# Altium schematic sheet AD9833-DDS.SchDoc
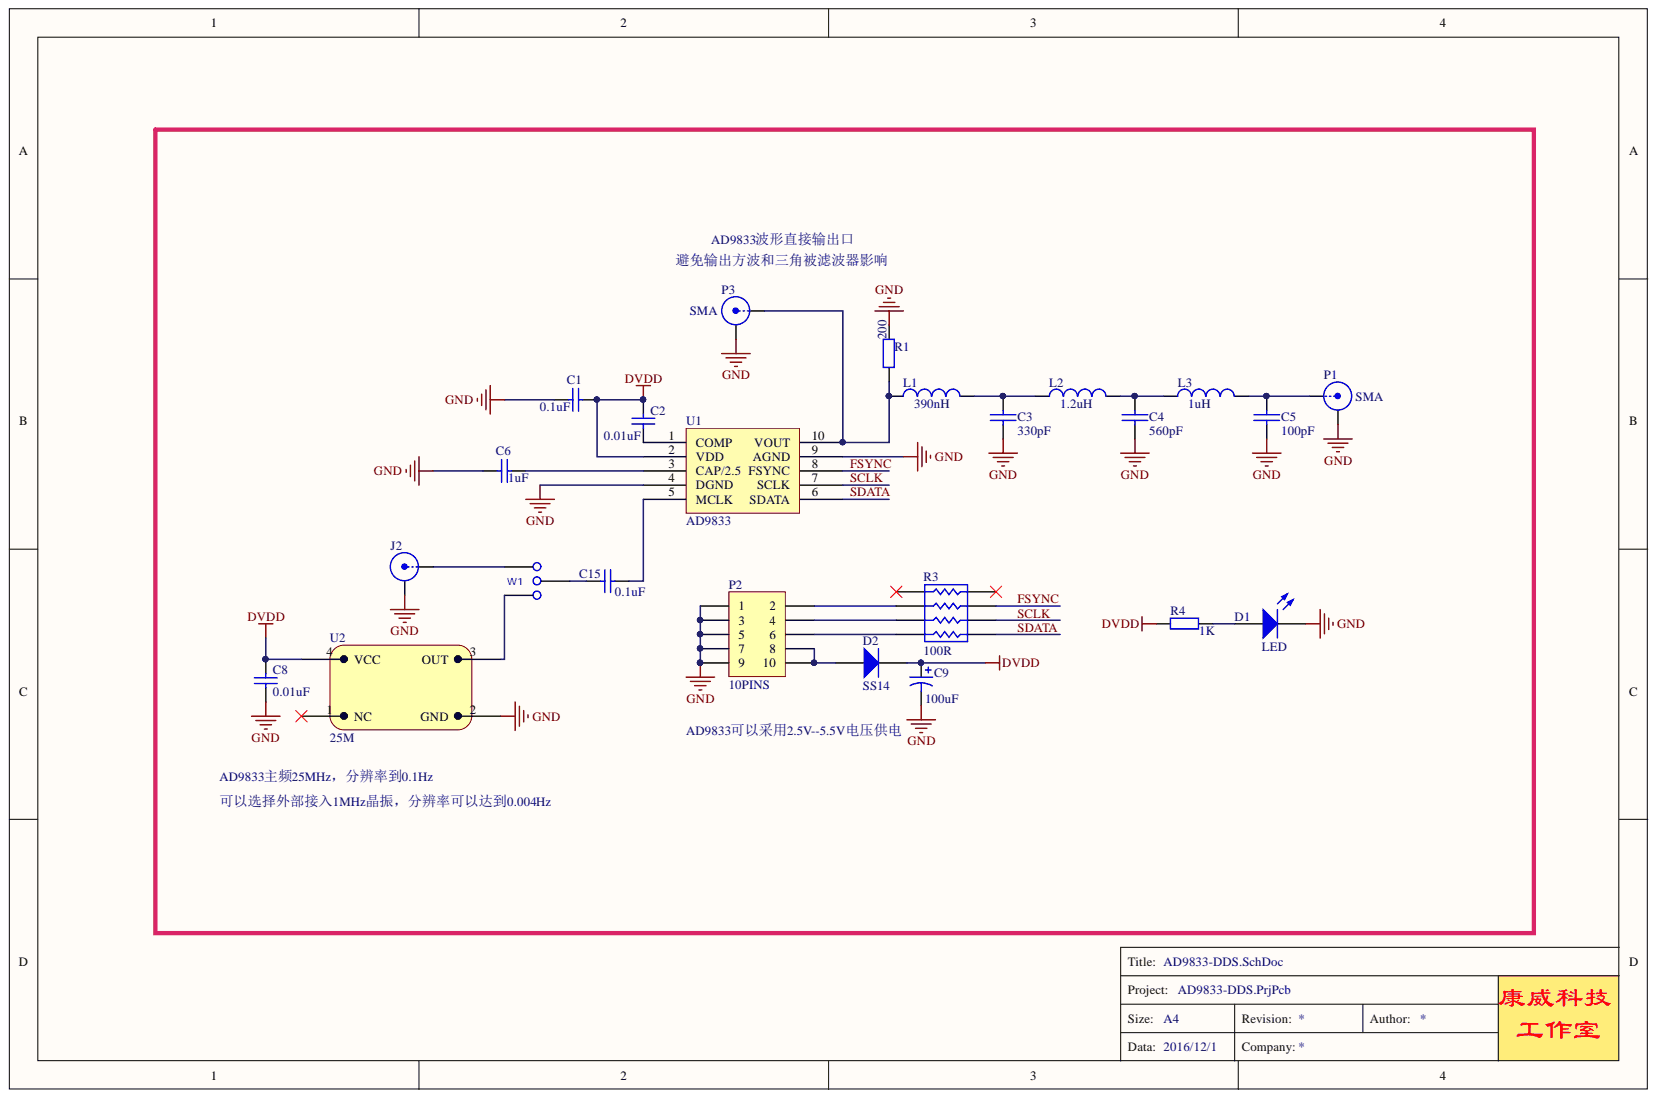
[diagram p0: AD9833-DDS.SchDoc]

## Parts
C1  value 0.1uF  [C0805]
C2  value 0.01uF  [C0805]
C3  330pF  [C0805]
C4  560pF  [C0805]
C5  100pF  [C0805]
C6  value 1uF  [C0805]
C8  value 0.01uF  [C0805]
C9  value 100uF  [C1210]
C15  value 0.1uF  [C0805]
D1  LED  [LED0805R]
D2  SS14  [SOD123]
J2  SMB  [SM]  (value not drawn)
L1  390nH  [L0805]
L2  1.2uH  [L0805]
L3  1uH  [L0805]
P1  SMA  [SM]
P2  10PINS  [IDC-2.54mm-10P]
P3  SMA  [SM]
R1  value 200  [R0805]
R3  100R  [RA4]
R4  value 1K  [R0805]
U1  AD9833  [AD9833]
U2  25M  [XTAL_7050]
W1  key  [HDR1X3]  (value not drawn)

## Nets
DVDD: C1.1 C2.2 C8.2 C9.1 D2.2 R4.1 U1.2(VDD) U2.4(VCC)
GND: C1.2 C3.2 C4.2 C5.2 C6.2 C8.1 C9.2 D1.2 J2.2 P1.2 P2.1 P2.3 P2.5 P2.7 P2.9 P3.2 R1.2 U1.4(DGND) U1.9(AGND) U2.2(GND)
FSYNC: R3.4 U1.8(FSYNC)
SCLK: R3.6 U1.7(SCLK)
SDATA: R3.8 U1.6(SDATA)
NetC2_1: C2.1 U1.1(COMP)
NetC3_1: C3.1 L1.1 L2.2
NetC4_1: C4.1 L2.1 L3.2
NetC5_1: C5.1 L3.1 P1.1
NetC6_1: C6.1 U1.3(CAP/2.5)
NetC15_1: C15.1 U1.5(MCLK)
NetC15_2: C15.2 W1.2
NetD1_1: D1.1 R4.2
NetD2_1: D2.1 P2.8 P2.10
NetJ2_1: J2.1 W1.1
NetL1_2: L1.2 P3.1 R1.1 U1.10(VOUT)
NetP2_2: P2.2 R3.3
NetP2_4: P2.4 R3.5
NetP2_6: P2.6 R3.7
NetU2_3: U2.3(OUT) W1.3

Not connected: R3 1 2; U2 1(NC)

## Library data of the parts
C1-C6 C8 C15 = Cap: Capacitor; Component Kind: Standard
  C1 C2 C6 C8 C15: LatestRevisionDate: 17-Jul-2002; LatestRevisionNote: Re-released for DXP Platform.; Library Name: Miscellaneous Devices.IntLib; PackageDescription: Radial Cap, Thru-Hole; 2 Leads; 0.3 in Pin Spacing; PackageReference: RAD-0.3; Published: 8-Jun-2000; Signal Integrity: Cap; Simulation: CAP; Publisher: Altium Limited
  C3-C5: Library Name: Miscellaneous Devices.SchLib
C9 = Cap Pol1: Polarized Capacitor (Radial); Component Kind: Standard; LatestRevisionDate: 17-Jul-2002; LatestRevisionNote: Re-released for DXP Platform.; Library Name: Miscellaneous Devices.IntLib; PackageDescription: Polarized Capacitor (Radial); 2 Leads; PackageReference: RB7.6-15; Published: 8-Jun-2000; Signal Integrity: Cap Pol; Simulation: CAP; Publisher: Altium Limited
D2 = Diode 1N4007: 1 Amp General Purpose Rectifier; Code_JEDEC: DO-204-AL; Component Kind: Standard; ComponentLink1Description: Manufacturer Link; ComponentLink1URL: http://www.fairchildsemi.com/; ComponentLink2Description: Datasheet; ComponentLink2URL: http://www.fairchildsemi.com/ds/1N%2F1N4001.pdf; DatasheetVersion: 1998; LatestRevisionDate: 17-Jul-2002; LatestRevisionNote: Re-released for DXP Platform.; Library Name: Miscellaneous Devices.IntLib; PackageDescription: Diode, Axial; Body 5.2 x 2.7 mm (LxDia. max), Lead Dia. 0.86 mm (max); PackageReference: DO-41; PackageVersion: Aug-1999; Published: 8-Jun-2000; Signal Integrity: 1N4007; Simulation: 1N4007; Publisher: Altium Limited
J2 P1 P3 = SMB: SMB Straight Connector; Component Kind: Standard; LatestRevisionDate: 17-Jul-2002; LatestRevisionNote: Re-released for DXP Platform.; Signal Integrity: Connector; Publisher: Altium Limited
  J2: Library Name: Miscellaneous Connectors.IntLib
  P1 P3: Library Name: Miscellaneous Devices.SchLib
L1-L3 = Inductor1: Inductor; Code_IEC: 1005; Value: 10mH; Code_JEITA: 0402; Component Kind: Standard; LatestRevisionDate: 29-May-2009; LatestRevisionNote: IPC-7351 Footprint Added.; Library Name: Miscellaneous Devices.SchLib; PackageDescription: Chip Inductor; PackageReference: 0402-A; Published: 8-Jun-2000; Signal Integrity: Inductor; Simulation: INDUCTOR; Publisher: Altium Limited
P2 = Header 5X2: Header, 5-Pin, Dual row; Component Kind: Standard; LatestRevisionDate: 17-Jul-2002; LatestRevisionNote: Re-released for DXP Platform.; Signal Integrity: Connector; Library Name: Xiaowei.SchLib; Publisher: Altium Limited
R1 R4 = Res2: Resistor; Component Kind: Standard; LatestRevisionDate: 17-Jul-2002; LatestRevisionNote: Re-released for DXP Platform.; Library Name: Miscellaneous Devices.IntLib; PackageDescription: Axial Device, Thru-Hole; 2 Leads; 0.4 in Pin Spacing; PackageReference: AXIAL-0.4; Published: 8-Jun-2000; Signal Integrity: Res; Simulation: RESISTOR; Publisher: Altium Limited
R3 = RESPACK1: Component Kind: Standard; Library Name: AD9854.SCHLIB
U2 = CRYS2: Component Kind: Standard
W1 = W: KEY 1 to 2; Component Kind: Standard
D1 = LED: Component Kind: Standard; LatestRevisionDate: 08-Jul-2005; LatestRevisionNote: Mech Layer 1 removed.; PackageDescription: LED; 2 Leads; PackageReference: LED-0; Published: 8-Jun-2000; Library Name: Xiaowei.SchLib; Publisher: Altium Limited
U1 = AD9833: Component Kind: Standard; Library Name: Xiaowei.SchLib
